# Altium schematic sheet LIDO-HW.100.FrontConnector.SchDoc(LIDO-HW.100.FrontConnector)
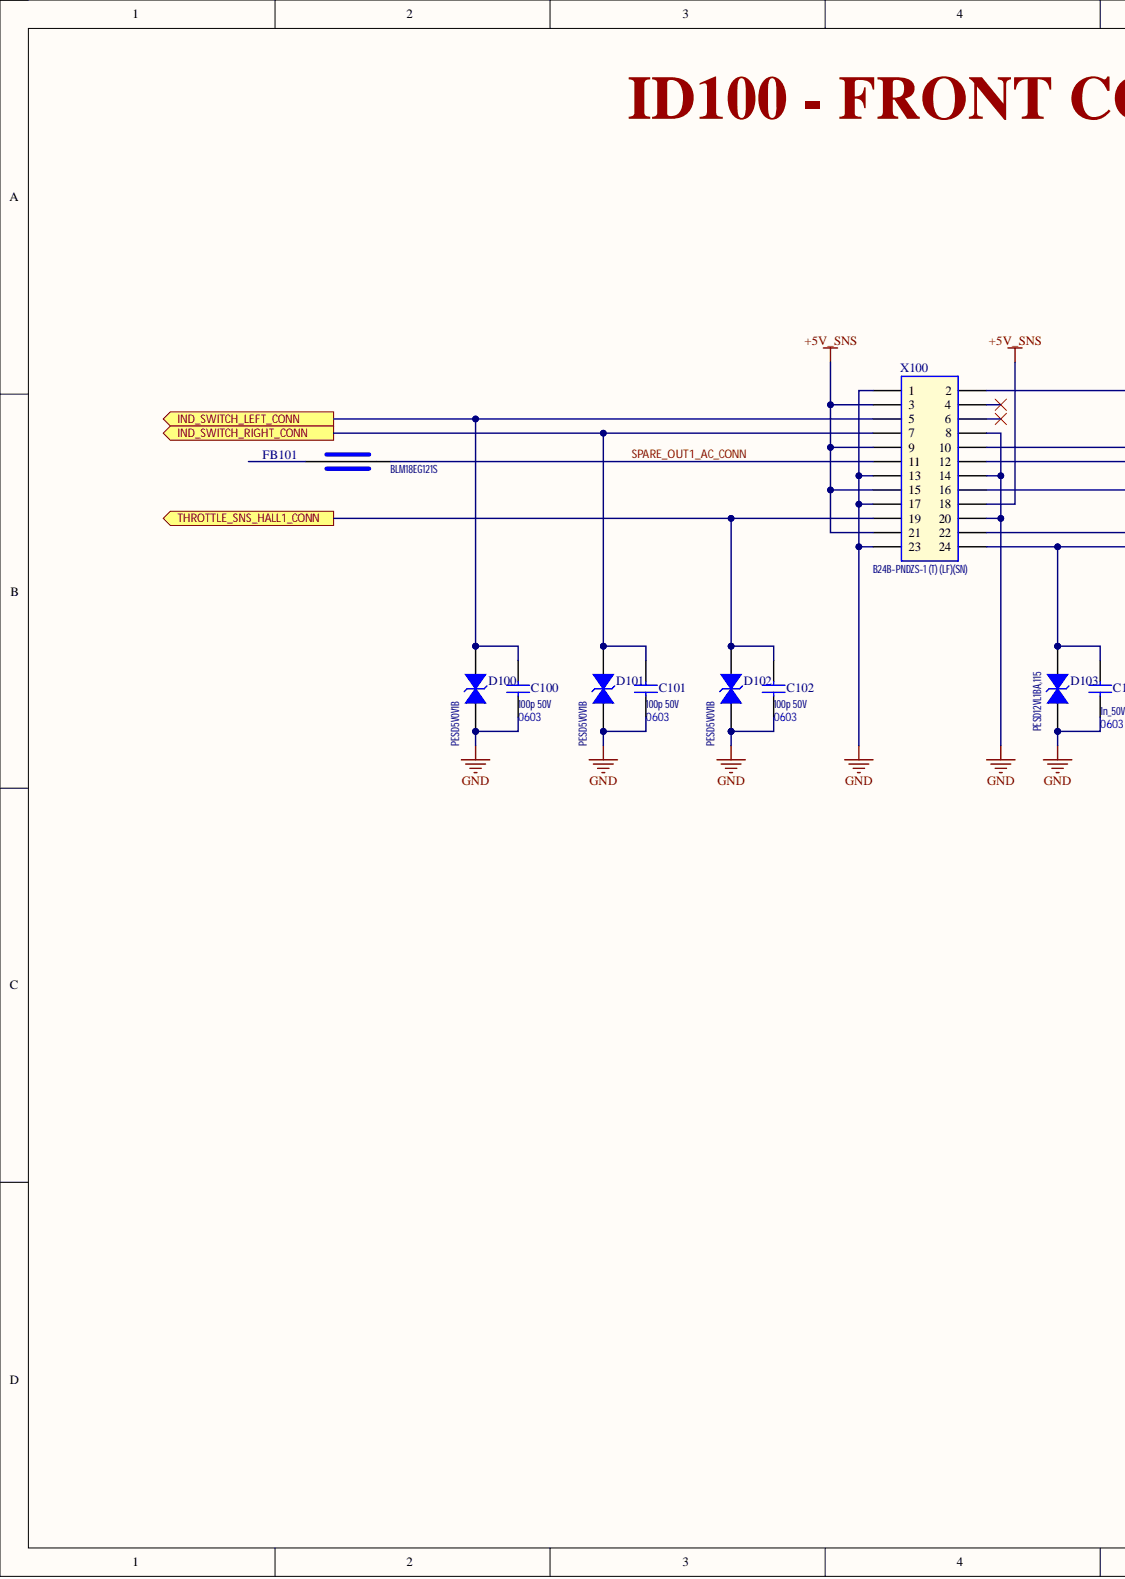
[diagram p0: LIDO-HW.100.FrontConnector.SchDoc, left]
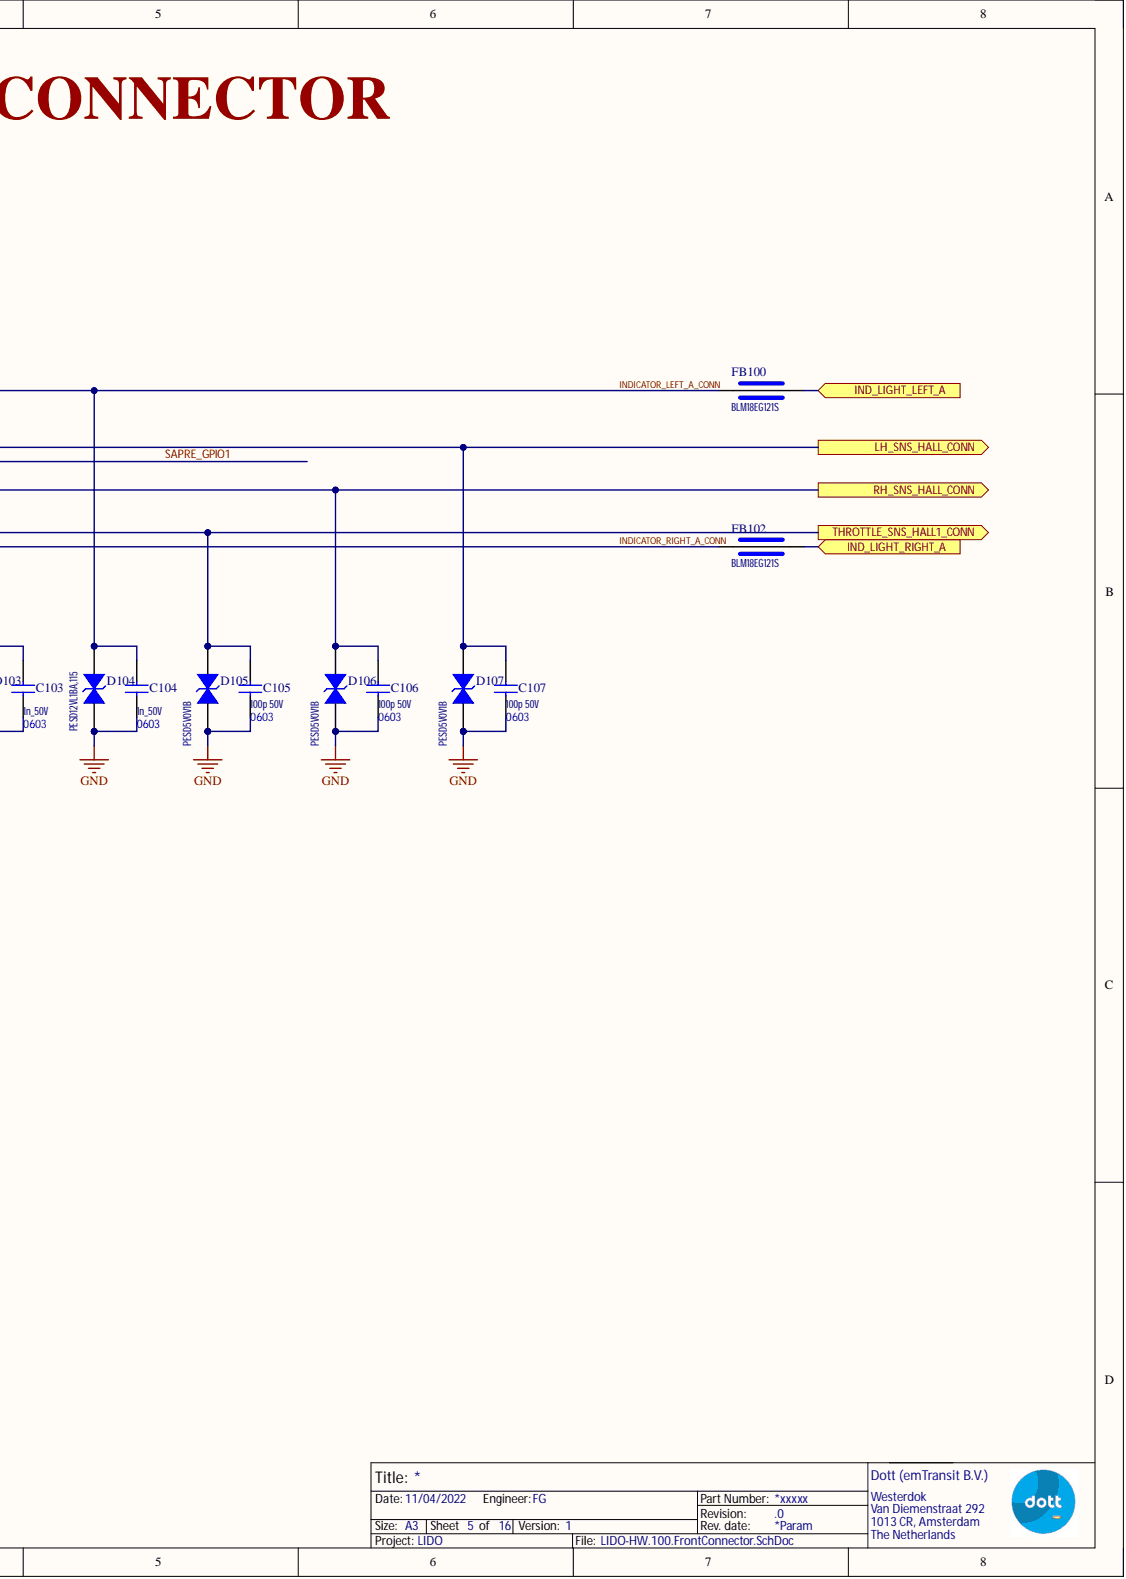
[diagram p1: LIDO-HW.100.FrontConnector.SchDoc, right]

## Parts
C100  100p 50V
C101  100p 50V
C102  100p 50V
C103  1n_50V
C104  1n_50V
C105  100p 50V
C106  100p 50V
C107  100p 50V
D100  PESD5V0V1B
D101  PESD5V0V1B
D102  PESD5V0V1B
D103  PESD12VL1BA,115
D104  PESD12VL1BA,115
D105  PESD5V0V1B
D106  PESD5V0V1B
D107  PESD5V0V1B
FB100  BLM18EG121S
FB101  BLM18EG121S
FB102  BLM18EG121S
X100  B24B-PNDZS-1 (T) (LF)(SN)

## Ports
IND_LIGHT_LEFT_A, IND_LIGHT_RIGHT_A, IND_SWITCH_LEFT_CONN, IND_SWITCH_RIGHT_CONN, LH_SNS_HALL_CONN, RH_SNS_HALL_CONN, THROTTLE_SNS_HALL1_CONN

## Nets
+5V_SNS: X100.3 X100.9 X100.15 X100.18 X100.21
GND: C100.1 C101.1 C102.1 C103.1 C104.1 C105.1 C106.1 C107.1 D100.A D101.A D102.A D103.A D104.A D105.A D106.A D107.A X100.1 X100.8 X100.13 X100.14 X100.17 X100.20 X100.23
IND_LIGHT_LEFT_A: FB100.1 ; port IND_LIGHT_LEFT_A
IND_LIGHT_RIGHT_A: FB102.1 ; port IND_LIGHT_RIGHT_A
IND_SWITCH_LEFT_CONN: C100.2 D100.C X100.5 ; port IND_SWITCH_LEFT_CONN
IND_SWITCH_RIGHT_CONN: C101.2 D101.C X100.7 ; port IND_SWITCH_RIGHT_CONN
INDICATOR_LEFT_A_CONN: C104.2 D104.C FB100.2 X100.2
INDICATOR_RIGHT_A_CONN: C103.2 D103.C FB102.2 X100.24
LH_SNS_HALL_CONN: C107.2 D107.C X100.10 ; port LH_SNS_HALL_CONN
RH_SNS_HALL_CONN: C106.2 D106.C X100.16 ; port RH_SNS_HALL_CONN
SAPRE_GPIO1: X100.12
SPARE_OUT1_AC_CONN: FB101.2 X100.11
THROTTLE_SNS_HALL1_CONN: C102.2 C105.2 D102.C D105.C X100.19 X100.22 ; port THROTTLE_SNS_HALL1_CONN

Not connected: FB101 1; X100 4 6

## Library data of the parts
C100-C107 = Ceramic: Ceramic CAP Symbol; Component Kind: Standard; Library Name: 01_Capacitors.SchLib
D100-D107 = BI-TVS_DIODE_B: Bidirectional TVS Diode Symbol; Component Kind: Standard; Library Name: 04_Circuit_Protection.SchLib
FB100-FB102 = FERRITE_BEAD: Ferrite Bead Symbol; Component Kind: Standard; Library Name: 08_Filters.SchLib
X100 = RECT_24PIN_2R_A: Rectengular connector, 24 pins, 2 row, Version A; Component Kind: Standard; Library Name: 20_Connectors_Rectangular.SchLib
